# Altium schematic sheet Tutorial - Racer Schematic.SchDoc
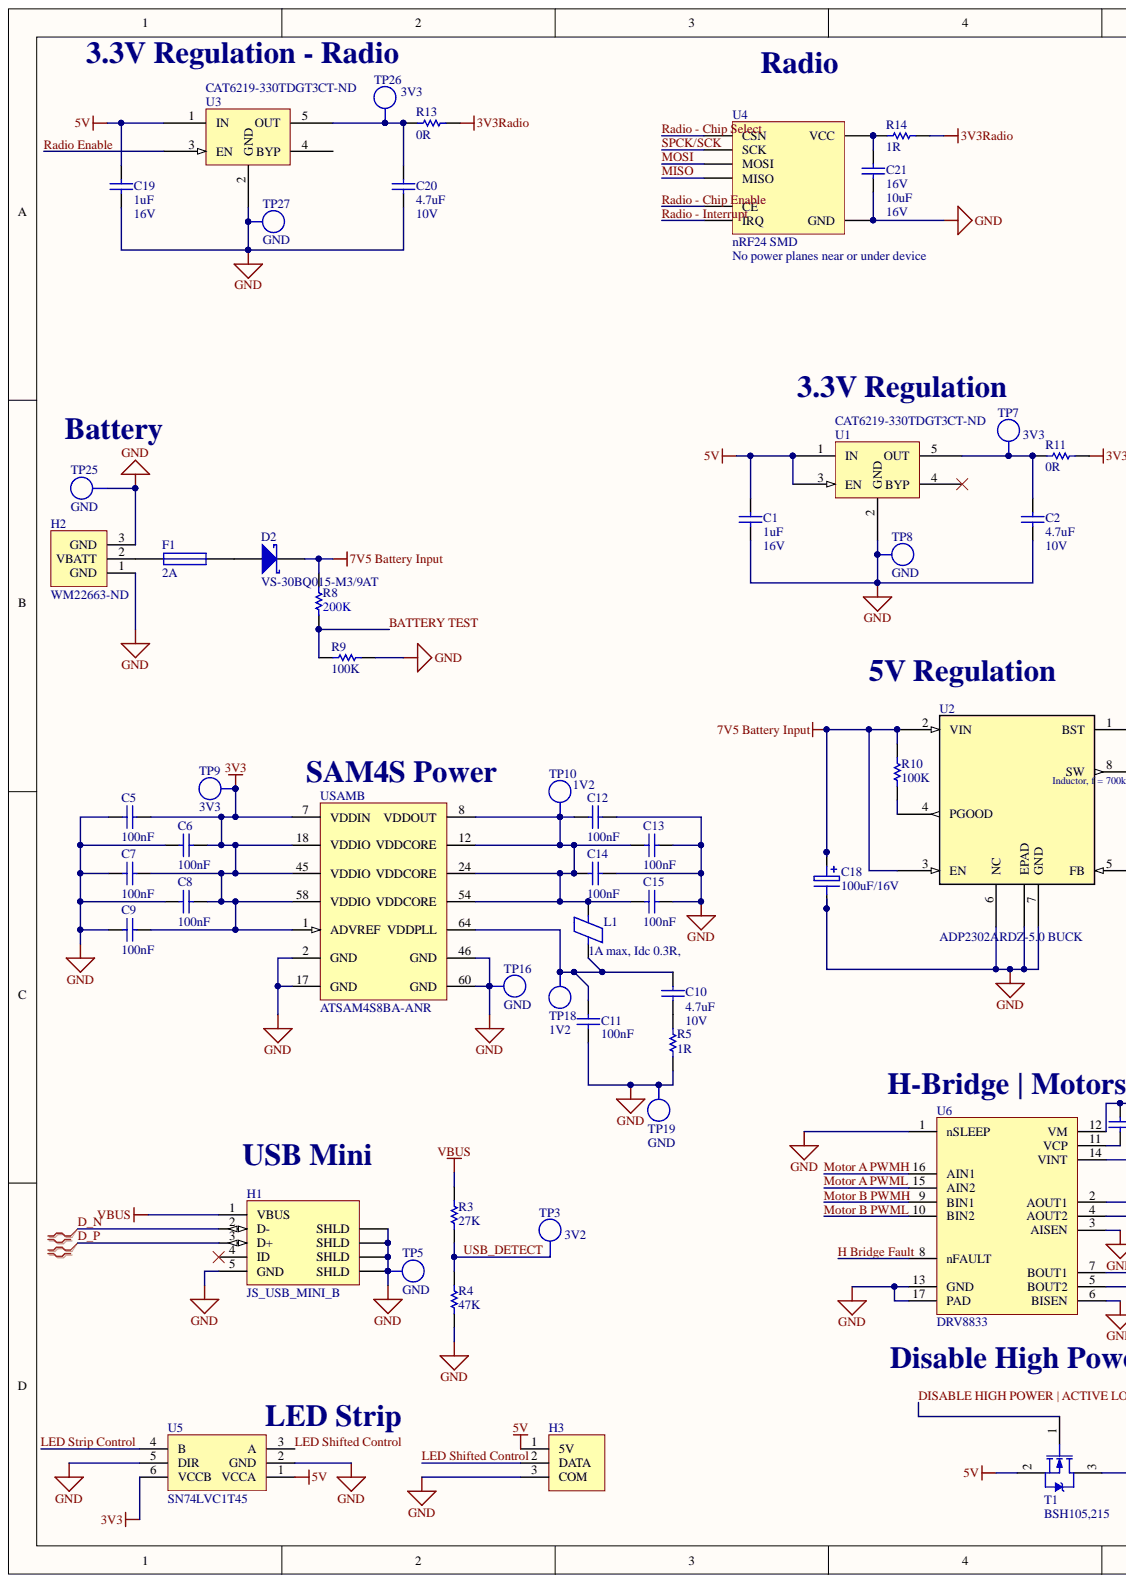
[diagram p0: Tutorial - Racer Schematic.SchDoc, left]
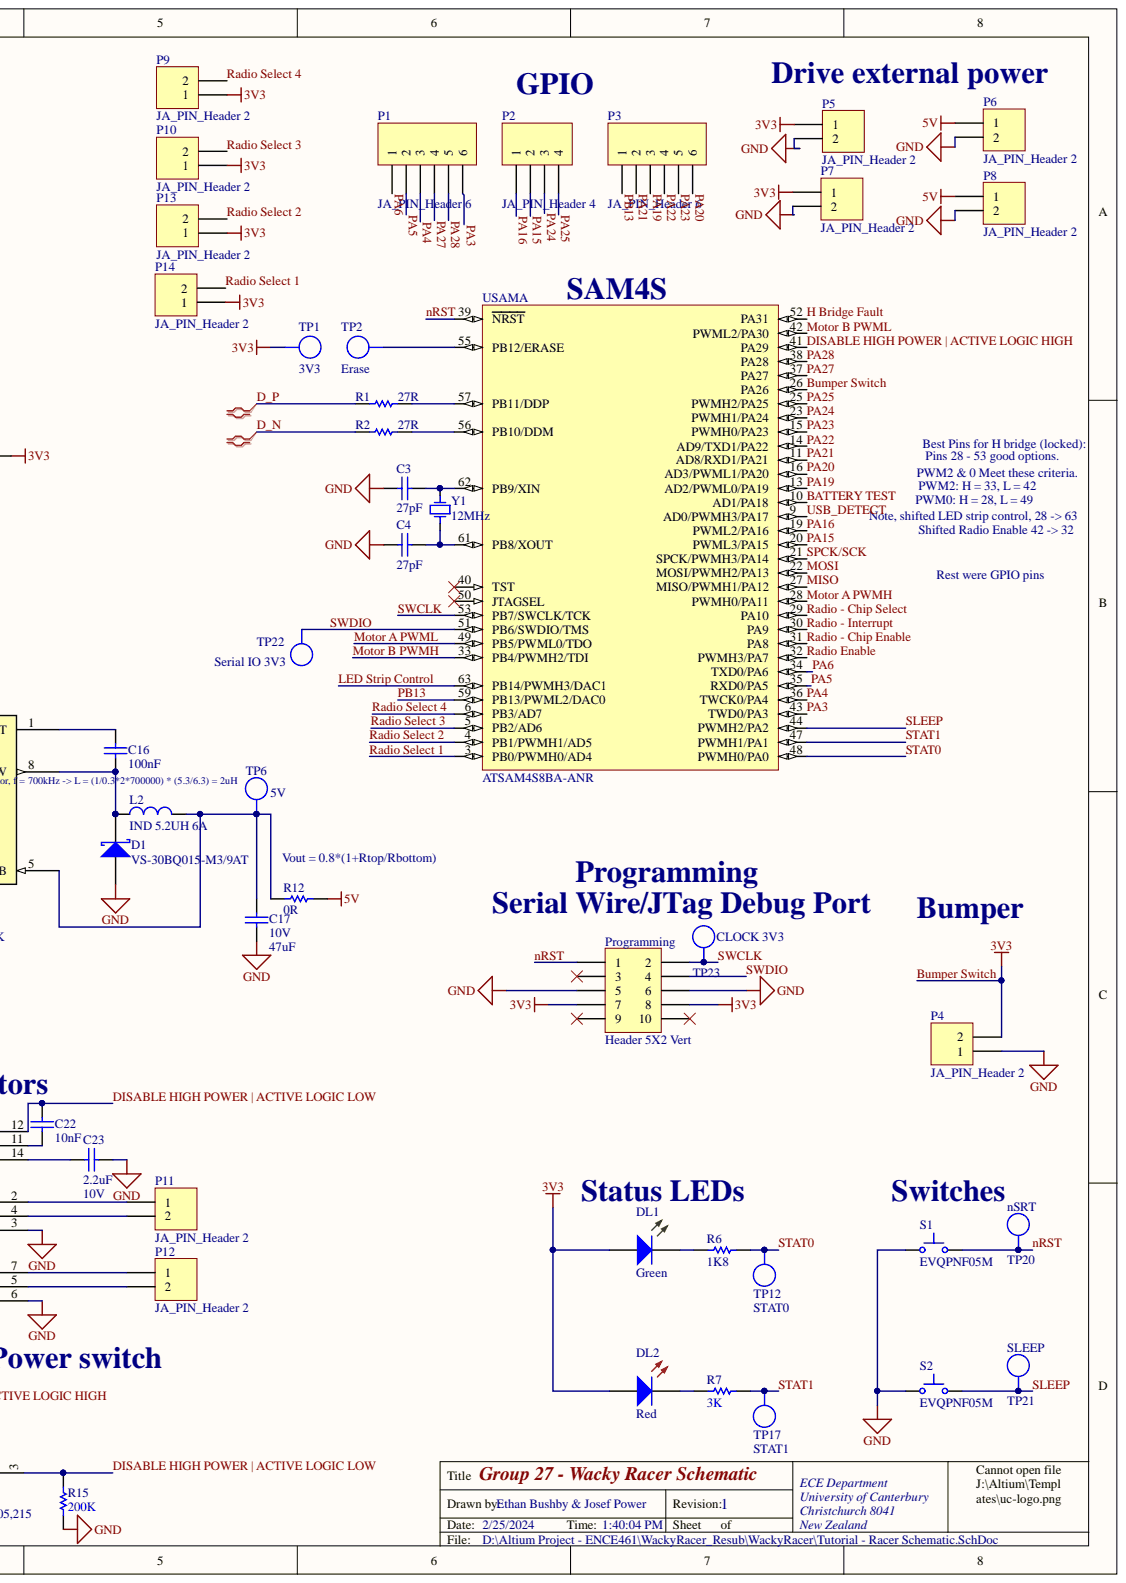
[diagram p1: Tutorial - Racer Schematic.SchDoc, right]

## Parts
C1  1uF  Max Voltage: 16V  [CAPC1608L]
C2  4.7uF  Max Voltage: 10V  [CAPC1608N]
C3  27pF  [CAPC1608L]
C4  27pF  [CAPC1608L]
C5  100nF  [CAPC1608L]
C6  100nF  [CAPC1608L]
C7  100nF  [CAPC1608L]
C8  100nF  [CAPC1608L]
C9  100nF  [CAPC1608L]
C10  4.7uF  Max Voltage: 10V  [CAPC1608N]
C11  100nF  [CAPC1608L]
C12  100nF  [CAPC1608L]
C13  100nF  [CAPC1608L]
C14  100nF  [CAPC1608L]
C15  100nF  [CAPC1608L]
C16  100nF  [CAPC1608L]
C17  47uF  Max Voltage: 10V  [1210 (3225 metric)]
C18  100uF/16V  [NICH-1]
C19  1uF  Max Voltage: 16V  [CAPC1608L]
C20  4.7uF  Max Voltage: 10V  [CAPC1608N]
C21  10uF  Max Voltage: 16V  [CAPC2012L]
C22  10nF  [CAPC1608L]
C23  2.2uF  Max Voltage: 10V  [CAPC1608L]
D1  VS-30BQ015-M3/9AT  [SMC]
D2  VS-30BQ015-M3/9AT  [SMC]
DL1  Green  [WL-SMCW0603]
DL2  Red  [WL-SMCW0603]
F1  2A  [RESC3216N]
H1  JS_USB_MINI_B  [USB/SM0.8-6H5]
H2  Supplier Part Number 1: WM22663-ND  [BATT_HEADER_3POS]  (hidden comment: Battery_HEADER_3pin)
H3  3 Pin Molex KK Hdr  [MOLEX_KK_3_HDR]  (value not drawn)
L1  1A max, Idc 0.3R,  [INDC3216L]
L2  IND 5.2UH 6A  [INDUCTOR5u2]
P1  JA_PIN_Header 6  [HDR1X6]
P2  JA_PIN_Header 4  [HDR1X4]
P3  JA_PIN_Header 6  [HDR1X6]
P4  JA_PIN_Header 2  [HDR1X2]
P5  JA_PIN_Header 2  [HDR1X2]
P6  JA_PIN_Header 2  [HDR1X2]
P7  JA_PIN_Header 2  [HDR1X2]
P8  JA_PIN_Header 2  [HDR1X2]
P9  JA_PIN_Header 2  [HDR1X2]
P10  JA_PIN_Header 2  [HDR1X2]
P11  JA_PIN_Header 2  [HDR1X2]
P12  JA_PIN_Header 2  [HDR1X2]
P13  JA_PIN_Header 2  [HDR1X2]
P14  JA_PIN_Header 2  [HDR1X2]
Programming  Header 5X2 Vert  [IDC2.54-V10D]
R1  27R  [RESC1608L]
R2  27R  [RESC1608L]
R3  27K  [RESC1608L]
R4  47K  [RESC1608N]
R5  1R  [RESC3216L]
R6  1K8  [RESC1608L]
R7  3K  [RESC1608L]
R8  200K  [RESC1608L]
R9  100K  [RESC1608L]
R10  100K  [RESC1608L]
R11  0R  [RESC3216L]
R12  0R  [RESC3216L]
R13  0R  [RESC3216L]
R14  1R  [RESC3216L]
R15  200K  [RESC1608L]
S1  EVQPNF05M  [EVQPNF05M]
S2  EVQPNF05M  [EVQPNF05M]
T1  BSH105,215  [SOT23_L]
TP1  3V3  [TP_1206]  (no BOM)
TP2  Erase  [TP_1206]  (no BOM)
TP3  3V2  [TP_1206]  (no BOM)
TP5  GND  [TP_1206]  (no BOM)
TP6  5V  [TP_1206]  (no BOM)
TP7  3V3  [TP_1206]  (no BOM)
TP8  GND  [TP_1206]  (no BOM)
TP9  3V3  [TP_1206]  (no BOM)
TP10  1V2  [TP_1206]  (no BOM)
TP12  STAT0  [TP_1206]  (no BOM)
TP16  GND  [TP_1206]  (no BOM)
TP17  STAT1  [TP_1206]  (no BOM)
TP18  1V2  [TP_1206]  (no BOM)
TP19  GND  [TP_1206]  (no BOM)
TP20  nSRT  [TP_1206]  (no BOM)
TP21  SLEEP  [TP_1206]  (no BOM)
TP22  Serial IO 3V3  [TP_1206]  (no BOM)
TP23  CLOCK 3V3  [TP_1206]  (no BOM)
TP25  GND  [TP_1206]  (no BOM)
TP26  3V3  [TP_1206]  (no BOM)
TP27  GND  [TP_1206]  (no BOM)
U1  CAT6219-330TDGT3  Supplier Part Number: CAT6219-330TDGT3CT-ND  [SOT95P280X145-5N]
U2  ADP2302ARDZ-5.0 BUCK  Frequency - Switching: 700kHz  Voltage - Output (Min/Fixed): 5V  [RD_8_1]
U3  CAT6219-330TDGT3  Supplier Part Number: CAT6219-330TDGT3CT-ND  [SOT95P280X145-5N]
U4  nRF24 SMD  Warning: No power planes near or under device  [NRF24]
U5  SN74LVC1T45  [SOT23-6_N]
U6  DRV8833  [HTSOP16 DRV8833]
USAM  ATSAM4S8BA-ANR  [LQFP64_L]  (parts A,B)
Y1  12MHz  [6.0X3.5-4]

## Nets
3V3Radio: R13.2 R14.2
5V: C1.2 C19.2 H3.1 P6.1 P8.1 R12.2 T1.2 U1.1(IN) U1.3(EN) U3.1(IN) U5.1(VCCA)
7V5 Battery Input: C18.2 D2.2 R8.2 R10.2 U2.2(VIN) U2.3(EN)
GND: C1.1 C2.1 C3.1 C4.1 C5.1 C6.1 C7.1 C8.1 C9.1 C11.1 C12.2 C13.2 C14.2 C15.2 C17.1 C18.1 C19.1 C20.1 C21.1 C23.2 D1.1 H1.5(GND) H1.6(SHLD) H1.7(SHLD) H1.8(SHLD) H1.9(SHLD) H2.1 H2.3 H3.3 P4.1 P5.2 P6.2 P7.2 P8.2 Programming.5 Programming.6 R4.1 R5.1 R9.2 R15.1 S1.1 S2.1 TP5.1 TP8.1 TP16.1 TP19.1 TP25.1 TP27.1 U1.2(GND) U2.6(NC) U2.7(GND) U2.EPAD U3.2(GND) U4.2(GND) U5.2(GND) U5.5(DIR) U6.1(nSLEEP) U6.3(AISEN) U6.6(BISEN) U6.13(GND) U6.17(PAD) USAM.2(GND) USAM.17(GND) USAM.46(GND) USAM.60(GND)
VBUS: H1.1(VBUS) R3.2
BATTERY TEST: R8.1 R9.1 USAM.10(AD1/PA18)
Bumper Switch: C5.2 C6.2 C7.2 C8.2 C9.2 DL1.2 DL2.2 P4.2 P5.1 P7.1 P9.1 P10.1 P13.1 P14.1 Programming.7 Programming.8 R11.2 TP1.1 TP9.1 U5.6(VCCB) USAM.1(ADVREF) USAM.7(VDDIN) USAM.18(VDDIO) USAM.26(PA26) USAM.45(VDDIO) USAM.58(VDDIO)
D_N: H1.2(D-) R2.1
D_P: H1.3(D+) R1.1
DISABLE HIGH POWER | ACTIVE LOGIC HIGH: T1.1 USAM.41(PA29)
DISABLE HIGH POWER | ACTIVE LOGIC LOW: C22.2 R15.2 T1.3 U6.12(VM)
H Bridge Fault: U6.8(nFAULT) USAM.52(PA31)
LED Shifted Control: H3.2 U5.3(A)
LED Strip Control: U5.4(B) USAM.63(PB14/PWMH3/DAC1)
MISO: U4.7(MISO) USAM.27(MISO/PWMH1/PA12)
MOSI: U4.6(MOSI) USAM.22(MOSI/PWMH2/PA13)
Motor A PWMH: U6.16(AIN1) USAM.28(PWMH0/PA11)
Motor A PWML: U6.15(AIN2) USAM.49(PB5/PWML0/TDO)
Motor B PWMH: U6.9(BIN1) USAM.33(PB4/PWMH2/TDI)
Motor B PWML: U6.10(BIN2) USAM.42(PWML2/PA30)
nRST: Programming.1 S1.2 TP20.1 USAM.39(NRST)
PA3: P1.6 USAM.43(TWD0/PA3)
PA4: P1.3 USAM.36(TWCK0/PA4)
PA5: P1.2 USAM.35(RXD0/PA5)
PA6: P1.1 USAM.34(TXD0/PA6)
PA15: P2.2 USAM.20(PWML3/PA15)
PA16: P2.1 USAM.19(PWML2/PA16)
PA19: P3.3 USAM.13(AD2/PWML0/PA19)
PA20: P3.6 USAM.16(AD3/PWML1/PA20)
PA21: P3.2 USAM.11(AD8/RXD1/PA21)
PA22: P3.4 USAM.14(AD9/TXD1/PA22)
PA23: P3.5 USAM.15(PWMH0/PA23)
PA24: P2.3 USAM.23(PWMH1/PA24)
PA25: P2.4 USAM.25(PWMH2/PA25)
PA27: P1.4 USAM.37(PA27)
PA28: P1.5 USAM.38(PA28)
PB13: P3.1 USAM.59(PB13/PWML2/DAC0)
Radio - Chip Enable: U4.3(Enable) USAM.31(PA8)
Radio - Chip Select: U4.4(Select) USAM.29(PA10)
Radio - Interrupt: U4.8(IRQ) USAM.30(PA9)
Radio Enable: U3.3(EN) USAM.32(PWMH3/PA7)
Radio Select 1: P14.2 USAM.3(PB0/PWMH0/AD4)
Radio Select 2: P13.2 USAM.4(PB1/PWMH1/AD5)
Radio Select 3: P10.2 USAM.5(PB2/AD6)
Radio Select 4: P9.2 USAM.6(PB3/AD7)
SLEEP: S2.2 TP21.1 USAM.44(PWMH2/PA2)
SPCK/SCK: U4.5(SCK) USAM.21(SPCK/PWMH3/PA14)
STAT0: R6.2 TP12.1 USAM.48(PWMH0/PA0)
STAT1: R7.2 TP17.1 USAM.47(PWMH1/PA1)
SWCLK: Programming.2 TP23.1 USAM.53(PB7/SWCLK/TCK)
SWDIO: Programming.4 TP22.1 USAM.51(PB6/SWDIO/TMS)
USB_DETECT: R3.1 R4.2 TP3.1 USAM.9(AD0/PWMH3/PA17)
NetC2_2: C2.2 R11.1 TP7.1 U1.5(OUT)
NetC3_2: C3.2 USAM.62(PB9/XIN) Y1.3
NetC4_2: C4.2 USAM.61(PB8/XOUT) Y1.1
NetC10_1: C10.1 R5.2
NetC10_2: C10.2 C11.2 L1.1 TP18.1 USAM.64(VDDPLL)
NetC12_1: C12.1 C13.1 C14.1 C15.1 L1.2 TP10.1 USAM.8(VDDOUT) USAM.12(VDDCORE) USAM.24(VDDCORE) USAM.54(VDDCORE)
NetC16_1: C16.1 D1.2 L2.1 U2.8(SW)
NetC16_2: C16.2 U2.1(BST)
NetC17_2: C17.2 L2.2 R12.1 TP6.1 U2.5(FB)
NetC20_2: C20.2 R13.1 TP26.1 U3.5(OUT)
NetC21_2: C21.2 R14.1 U4.1(VCC)
NetC22_1: C22.1 U6.11(VCP)
NetC23_1: C23.1 U6.14(VINT)
NetD2_1: D2.1 F1.2
NetDL1_1: DL1.1 R6.1
NetDL2_1: DL2.1 R7.1
NetF1_1: F1.1 H2.2
NetP11_1: P11.1 U6.2(AOUT1)
NetP11_2: P11.2 U6.4(AOUT2)
NetP12_1: P12.1 U6.7(BOUT1)
NetP12_2: P12.2 U6.5(BOUT2)
NetR1_2: R1.2 USAM.57(PB11/DDP)
NetR2_2: R2.2 USAM.56(PB10/DDM)
NetR10_1: R10.1 U2.4(PGOOD)
NetTP2_1: TP2.1 USAM.55(PB12/ERASE)

Not connected: H1 4(ID); Programming 3 9 10; U1 4(BYP); U3 4(BYP); USAM 40(TST) 50(JTAGSEL)

## Library data of the parts
C1 C19 = CC_1uF_0603: CAP CER 1uF Y5V -20% +80% 16V 0603; Code_IPC: 1608 [0603]; Component Kind: Standard; Library Name: ECE AD19Components_22V1.IntLib; PackageReference: 0603; Simulation: CAP; Storage Location: PCB Assembly WS; Supplier: ECE Dept; Value: 1uF
C2 C10 C20 = CC_4.7uF_0603: CAP CER 4.7uF X5R +-20%  10V 0603; Note: Footprint corresponds to dimensions for bulk cassette packaging. This case size has tightened tolerances.; Code_IPC: 2012[0805]; Component Kind: Standard; Library Name: ECE AD19Components_22V1.IntLib; PackageReference: 0805; Simulation: CAP; Storage Location: PCB Assembly WS; Supplier: ECE Dept; Value: 4.7uF
C3 C4 = CC_27pF_0603: CAP CER 27pF NPO/COG +-5% 50V 0603; Code_IPC: 1608 [0603]; Component Kind: Standard; Library Name: ECE AD19Components_22V1.IntLib; PackageReference: 0603; Simulation: CAP; Storage Location: PCB Assembly WS; Supplier: ECE Dept; Value: 27pF
C5-C9 C11-C16 = CC_100nF_0603: CAP CER 100nF X7R +-10% 50V 0603; Code_IPC: 1608 [0603]; Component Kind: Standard; Library Name: ECE AD19Components_22V1.IntLib; PackageReference: 0603; Simulation: CAP; Storage Location: PCB Assembly WS; Supplier: ECE Dept; Value: 100nF
C17 = CC_47uF_1206: CAP CER 47uF X5R -/+20% 10V 1206; Component Kind: Standard; Dielectric: X5R; Library Name: ECE AD19Components_22V1.IntLib; MoV: 10V; Simulation: CAP; Storage Location: PCB Assembly WS; Supplier: ECE Dept; Value: 47uF
C18 = CA_100uF_16V: CAP ALUM 100uF 16V SMD; Manufacturer: Nichcon; Manufacturer PN: UWX1C101MCL1GB; Component Kind: Standard; Library Name: ECE AD19Components_22V1.IntLib; Storage Location: PCB Assembly WS; Supplier: ECE Dept; Supplier 1: Farnell; Supplier 2: Digi-Key; Supplier Part Number 1: 1870677; Supplier Part Number 2: 493-2105-1-ND; Value: 100uF/16V
C21 = CC_10uF_0805_16V: CAP CER 10uF X5R 16V 0805; Manufacturer: Samsung Electro-Mechanics; Manufacturer Part Number: CL21A106KOQNNWE; Note: Footprint corresponds to dimensions for bulk cassette packaging. This case size has tightened tolerances.; Notification: Current market demand for these product types have resulted in fluctuating and extending lead times. Lead times may differ.; Tolerance: ±10%; Applications: General Purpose; Category: Capacitors; Code_IPC: 2012[0805]; Component Kind: Standard; ComponentLink1Description: http://www.samsungsem.com/kr/support/product-search/mlcc/CL21A106KOQNNWE.jsp; ComponentLink1URL: http://www.samsungsem.com/kr/support/product-search/mlcc/CL21A106KOQNNWE.jsp; Features: -; Height - Seated (Max): -; Lead Spacing: -; Lead Style: -; Library Name: ECE AD19Components_22V1.IntLib; Mounting Type: Surface Mount, MLCC; Operating Temperature: -55°C ~ 85°C; Package / Case: 0805 (2012 Metric); PackageReference: 0805; Packaging: Cut Tape (CT); Part Status: Active; Pricing 1: 1=0.35, 10=0.25, 100=0.1178, 500=0.08254, 1000=0.06831 (NZD); Ratings: -; RoHS: RoHS Compliant; Simulation: CAP; Size / Dimension: 0.079" L x 0.049" W (2.00mm x 1.25mm); Stock 1: 20000; Supplier 1: Digi-Key; Supplier Part Number 1: 1276-2894-1-ND; Temperature Coefficient: X5R; Thickness (Max): 0.055" (1.40mm); Value: 10uF; Voltage - Rated: 16V
C22 = CC_10nF_0603: CAP CER 10nF X7R +-10% 50V 0603; Code_IPC: 1608 [0603]; Component Kind: Standard; Library Name: ECE AD19Components_22V1.IntLib; PackageReference: 0603; Simulation: CAP; Storage Location: PCB Assembly WS; Supplier: ECE Dept; Value: 10nF
C23 = CC_2.2uF_0603: CAP CER 2.2uF X5R +-20% 10V 0603; Code_IPC: 1608 [0603]; Component Kind: Standard; Library Name: ECE AD19Components_22V1.IntLib; PackageReference: 0603; Simulation: CAP; Storage Location: PCB Assembly WS; Supplier: ECE Dept; Value: 2.2uF
D1 D2 = DS_VS-30BQ015-M3: DIODE, SCHOTTKY, 3A, 15V, DO-214AB / SMC; Manufacturer: Vishay Semiconductors; Forward Voltage: 350mV; Max Forward Surge Current (Ifsm): 650A; Peak Reverse Current: 4mA; Average Rectified Current: 3A; Component Kind: Standard; ComponentLink1Description: https://datasheet.ciiva.com/36181/vs-30bq015-m3-9at-36181258.pdf; ComponentLink1URL: https://datasheet.ciiva.com/36181/vs-30bq015-m3-9at-36181258.pdf; Library Name: ECE AD19Components_22V1.IntLib; Manufacturer Part Number: VS-30BQ015-M3/9AT; Max Reverse Voltage (DC): 15V; Supplier: Digi-Key; Supplier 1: Digi-Key; Supplier 2: Element14; Supplier Part Number: VS-30BQ015-M3/9AT-ND; Supplier Part Number 1: VS-30BQ015-M3/9ATGIDKR-ND; Supplier Part Number 2: 2628288
DL1 = DL_150060GS75000: LED Green 430mcd 0603 SMD; Manufacturer: WURTH ELEKTRONIK; Forward Current If: 30; Forward Voltage: 3.2; Lens Shape: Rectangular; Luminous Intensity: 430; Viewing Angle: 140°; Wavelength Typ: 520; Component Kind: Standard; LED Colour: Green; LED Mounting: SMD; Library Name: ECE AD19Components_22V1.IntLib; Manufacturer Part Number: 150060GS75000; Storage Location: PCB Assembly WS; Supplier: ECE Dept; Supplier 1: Farnell; Supplier Part Number 1: 2322070
DL2 = DL_150060RS75000: LED Red 250mcd 0603 SMD; Manufacturer: WURTH ELEKTRONIK; Forward Current If: 30; Forward Voltage: 2; Lens Shape: Rectangular; Luminous Intensity: 250; Viewing Angle: 140°; Wavelength Typ: 625; Component Kind: Standard; LED Colour: Red; LED Mounting: SMD; Library Name: ECE AD19Components_22V1.IntLib; Manufacturer Part Number: 150060RS75000; Storage Location: PCB Assembly WS; Supplier: ECE Dept; Supplier 1: Farnell; Supplier Part Number 1: 2322071
F1 = FS_Fuse_2.0A: Fuse 2.0A Very Fast Acting 1206 SMD; Manufacturer: MULTICOMP; Manufacturer Part Number: MC12CF 002; Component Kind: Standard; Library Name: ECE AD19Components_22V1.IntLib; Manufacturer PN: MC12CF 002; Storage Location: PCB Assembly WS; Supplier: ECE Dept; Supplier 1: Farnell; Supplier Part Number 1: 1841029; Value: 2A
H1 = JS_USB_MINI_B_SMT: USB 2.0, Right Angle, SMT, B Type, Receptacle, 5 Position,; Manufacturer: FCI; Manufacturer PN: 10033526-N3212MLF; Component Kind: Standard; Library Name: ECE AD19Components_22V1.IntLib; Storage Location: PCB Assembly WS; Supplier: ECE Dept; Supplier 1: Farnell; Supplier Part Number 1: 1824416
H2 = Battery_HEADER_3pin: 3Pin Header 2.54mm spacing  Digikey #WM22663-ND; Component Kind: Standard; Library Name: ENCE461AD19_V23.IntLib; Supplier 1: Digi-Key
H3 = LED_Strip_Header: CONN HEADER 3POS 2.54mm VERT_Thru Hole; Component Kind: Standard; Library Name: ENCE461AD19_V23.IntLib; Manufacturer: Molex; Manufacturer PN: 22-23-2031; Storage Location: ECE Dept Store; Supplier: ECE Dept; Supplier 1: Farnell; Supplier Part Number 1: 9731156; Value: 3 Pin Molex KK Hdr
L1 = IN_Ferrite-Bead: Ferrite Bead, Rdc 0.3R, Z100MHZ 600R, Imax 1A, SMD 1206; Manufacturer: FAIR-RITE; Manufacturer Part Number: 2512066017Y1; DC Current Rating: 1; DC Resistance Max: 0.08; Ferrite Case Style: 1206 ^3216 Metric]; Frequency Range: 50MHz to 1; Impedance: 600; Impedance Tolerance: ± 25%; Component Kind: Standard; Ferrite Mounting: SMD; Library Name: ECE AD19Components_22V1.IntLib; Manufacturer PN: 2512066017Y1; Storage Location: PCB Assembly WS; Supplier: ECE Dept; Supplier 1: Farnell; Supplier Part Number 1: 1612060; Value: 1A max, Idc 0.3R,
L2 = CDRH104RNP-5R2NC: FIXED IND 5.2UH 6A 22 MOHM SMD; Manufacturer: Sumida America Components Inc.; Current - Saturation: 5.6A; DC Resistance (DCR): 22 mOhm Max; Material - Core: Ferrite; Shielding: Shielded; Tolerance: ±30%; Category: Inductors, Coils, Chokes; Component Kind: Standard; ComponentLink1Description: http://products.sumida.com/products/pdf/CDRH104R.pdf; ComponentLink1URL: http://products.sumida.com/products/pdf/CDRH104R.pdf; Frequency - Self Resonant: -; Height - Seated (Max): 0.157" (4.00mm); Library Name: ENCE461AD19_V23.IntLib; Manufacturer Part Number: CDRH104RNP-5R2NC; Mounting Type: Surface Mount; Operating Temperature: -40°C ~ 100°C; Package / Case: Nonstandard; Packaging: Cut Tape (CT); Part Status: Active; Pricing 1: 1=2.9, 10=2.678, 100=1.8743, 500=1.5173 (NZD); Q @ Freq: -; Ratings: -; RoHS: RoHS Compliant; Size / Dimension: 0.402" L x 0.394" W (10.20mm x 10.00mm); Stock 1: 3849; Supplier 1: Digi-Key; Supplier Device Package: -; Supplier Part Number 1: 308-2280-1-ND; Type: -
P1 P3 = JA_PIN_Header 6: Header, 6-Pin; Component Kind: Standard; LatestRevisionDate: 17-Jul-2002; LatestRevisionNote: Re-released for DXP Platform.; Library Name: ECE AD19Components_22V1.IntLib; Publisher: Altium Limited
P2 = JA_PIN_Header 4: Header, 4-Pin; Component Kind: Standard; LatestRevisionDate: 17-Jul-2002; LatestRevisionNote: Re-released for DXP Platform.; Library Name: ECE AD19Components_22V1.IntLib; Publisher: Altium Limited
P4-P14 = JA_PIN_Header 2: Header, 2-Pin; Component Kind: Standard; LatestRevisionDate: 17-Jul-2002; LatestRevisionNote: Re-released for DXP Platform.; Library Name: ECE AD19Components_22V1.IntLib; Publisher: Altium Limited
Programming = JA_HDR_IDC_5X2_VERT: IDC Header, 2,54mm, 10-Pin, Vert; Component Kind: Standard; Library Name: ECE AD19Components_22V1.IntLib; Storage Location: ECE Dept Store; Supplier: ECE Dept; Supplier 1: Farnell; Supplier Part Number 1: 1096985
R1 R2 = RS_27R: RES 27 OHM 1/10W 5% 0603 SMD; Component Kind: Standard; Library Name: ECE AD19Components_22V1.IntLib; Simulation: RESISTOR; Storage Location: PCB Assembly WS; Supplier: ECE Dept; Value: 27R
R3 = RS_27K: RES 27K OHM 1/10W 5% 0603 SMD; Component Kind: Standard; Library Name: ECE AD19Components_22V1.IntLib; Simulation: RESISTOR; Storage Location: PCB Assembly WS; Supplier: ECE Dept; Value: 27K
R4 = RS_47K: RES 47.0K OHM 1/10W 5% 0603 SMD; Component Kind: Standard; Library Name: ECE AD19Components_22V1.IntLib; Simulation: RESISTOR; Storage Location: PCB Assembly WS; Supplier: ECE Dept; Value: 47K
R5 R14 = RT_1R: RES 1R OHM 1/4W 5% 1206 SMD; Component Kind: Standard; Library Name: ECE AD19Components_22V1.IntLib; Storage Location: PCB Assembly WS; Supplier: ECE Dept; Value: 1R
R6 = RS_1K8: RES 1.8K OHM 1/10W 5% 0603 SMD; Component Kind: Standard; Library Name: ECE AD19Components_22V1.IntLib; Simulation: RES; Storage Location: PCB Assembly WS; Supplier: ECE Dept; Value: 1K8
R7 = RS_3K: RES 3K OHM 1/10W 5% 0603 SMD; Component Kind: Standard; Library Name: ECE AD19Components_22V1.IntLib; Simulation: RESISTOR; Storage Location: PCB Assembly WS; Supplier: ECE Dept; Value: 3K
R8 R15 = RS_200K: RES 200K OHM 1/10W 5% 0603 SMD; Component Kind: Standard; Library Name: ECE AD19Components_22V1.IntLib; Simulation: RES; Storage Location: PCB Assembly WS; Supplier: ECE Dept; Value: 200K
R9 R10 = RS_100K: RES 100K OHM 1/10W 5% 0603 SMD; Component Kind: Standard; Library Name: ECE AD19Components_22V1.IntLib; Simulation: RESISTOR; Storage Location: PCB Assembly WS; Supplier: ECE Dept; Value: 100K
R11-R13 = RT_0R: RES 0R OHM 1/8W 5% 1206 SMD; Component Kind: Standard; Library Name: ECE AD19Components_22V1.IntLib; Storage Location: PCB Assembly WS; Supplier: ECE Dept; Value: 0R
S1 S2 = WS_EVQPNF05M: Panasonic 6 x 3.5mm SMD Light Touch Switch Type SMD; Component Kind: Standard; Library Name: ECE AD19Components_22V1.IntLib; Manufacturer: Panasonic; Manufacturer PN: EVQPNF05M; PackageDescription: 6.0 x 3.5 mm; Storage Location: PCB Assembly WS; Supplier: ECE Dept; Supplier 1: Farnell; Supplier 2: Digi-Key; Supplier Part Number 1: 2079481; Supplier Part Number 2: P13598SCT-ND
T1 = TM_BSH105: MOSFET N-CH 20V 1.05A SOT-23; Manufacturer: NXP Semiconductors; FET Type: MOSFET N-Channel, Metal Oxide; Gate Charge (Qg) @ Vgs: 3.9nC @ 4.5V; Input Capacitance (Ciss) @ Vds: 152pF @ 16V; Power - Max: 417mW; Rds On (Max) @ Id, Vgs: 200 mOhm @ 600mA, 4.5V; Component Kind: Standard; Current - Continuous Drain (Id) @ 25° C: 1.05A; Drain to Source Voltage (Vdss): 20V; Library Name: ECE AD19Components_22V1.IntLib; Manufacturer Part Number: BSH105,215; Package / Case: TO-236-3, SC-59, SOT-23-3; Pricing: 1=0.53, 10=0.42, 25=0.3544, 100=0.2885, 250=0.23896, 500=0.1974, 1000=0.14785 (NZD); Storage Location: PCB Assembly WS; Supplier: ECE Dept; Supplier 1: Farnell; Supplier 2: Digi-Key; Supplier Part Number 1: 1758066; Supplier Part Number 2: 568-6215-1-ND
TP1-TP3 TP5-TP10 TP12 TP16-TP23 TP25-TP27 = TP_1206: Test-Point 3.3x1.6x2.1mm SMD; Manufacturer: Harwin Inc; Manufacturer Part Number: S1751-46R; Value: TP; Library Name: ECE AD19Components_22V1.IntLib; Mounting Type: Surface Mount; Storage Location: ProtoPlace; Supplier: ECE Dept; Supplier 1: Digi-Key; Supplier 2: Farnell; Supplier Part Number 1: 952-1478-2-ND; Supplier Part Number 2: 1826282; Type: PC Test Point
U1 U3 = SV_CAT6219-330TDGT3: IC REG LIN 3.3V 500MA TSOT23-5; Voltage - Input (Max): 5.5V; Voltage Dropout (Max): 0.5V @ 500mA; Base Part Number: CAT6219; Component Kind: Standard; ComponentLink1Description: http://www.onsemi.com/pub/Collateral/CAT6219-D.PDF; ComponentLink1URL: http://www.onsemi.com/pub/Collateral/CAT6219-D.PDF; ComponentLink2Description: CAT6219 Datasheet; ComponentLink2URL: \\maxwell\elecapp\AltiumDesigner\Libraries\DatasheetsNew\ENCE461\CAT6219-D.PDF; Current - Output: 500mA; Library Name: ENCE461AD19_V23.IntLib; Manufacturer Part Number: CAT6219-330TDGT3; Package / Case: SOT-23-5 Thin, TSOT-23-5; Supplier: Digi-Key; Supplier 1: Digi-Key; Supplier Device Package: TSOT-23-5; Voltage - Output (Min/Fixed): 3.3V
U2 = ADP2302ARDZ-5.0-R7: IC REG BUCK 5V 2A 8SOIC; Output Type: Fixed; Vendor: Analog Devices Inc; Voltage - Input (Max): 20V; Component Kind: Standard; ComponentLink1Description: ADP2302ARDZ-5.0 Datasheet; ComponentLink1URL: \\maxwell\elecapp\AltiumDesigner\Libraries\DatasheetsNew\ENCE461\ADP2302_2303.pdf; Current - Output: 2A; Library Name: ENCE461AD19_V23.IntLib; Manufacturer_Part_Number: ADP2302ARDZ-5.0-R7; Supplier 1: Digi-Key; Supplier Part Number 1: ADP2302ARDZ-5.0-R7CT-ND; Type: DEV; Value: Value
U4 = NRF24: nRF24 SMD Module; Component Kind: Standard; Library Name: ENCE461AD19_V23.IntLib
U5 = SN74LVC1T45: 1.8V - 5V level converter; Component Kind: Standard; Library Name: ENCE461AD19_V23.IntLib
U6 = DRV8833: Dual H-Bridge Motor Driver 1.5A; Component Kind: Standard; ComponentLink1Description: DRV8833 Datasheet; ComponentLink1URL: \\maxwell\elecapp\AltiumDesigner\Libraries\DatasheetsNew\ENCE461\drv8833pwpr.pdf; Library Name: ENCE461AD19_V23.IntLib
USAM = SE_ATSAM4S16BA-MU: IC MCU 32BIT 512KB FLASH 64LQFP; Manufacturer: Atmel; Connectivity: I²C, IrDA, Memory Card, SPI, SSC, UART/USART, USB; Core Processor: ARM® Cortex®-M4; Core Size: 32-Bit; Data Converters: A/D 11x12b, D/A 2x12b; Number of I/O: 47; Peripherals: Brown-out Detect/Reset, DMA, POR, PWM, WDT; Program Memory Size: 512KB (512K x 8); RAM Size: 128K x 8; Speed: 120MHz; Voltage - Supply (Vcc/Vdd): 1.62 V ~ 3.6 V; Code_JEDEC: MO-220VMMD-3; Component Kind: Standard; ComponentLink1Description: Manufacturer URL; ComponentLink1URL: http://www.atmel.com/; ComponentLink2Description: SAM4S Series Datasheet; ComponentLink2URL: http://www.atmel.com/dyn/resources/prod_documents/11100.pdf; ComponentLink3Description: SAM4S Series Summary; ComponentLink3URL: http://www.atmel.com/dyn/resources/prod_documents/11100S.pdf; Library Name: ECE AD19Components_22V1.IntLib; Manufacturer Part Number: ATSAM4S8BA-ANR; Package / Case: 64-LQFP; PackageDescription: 64-Pin Quad Flat No-Lead (QFN) Sawn, 9 x 9 x 1.0 mm Body, 0.5 mm Pitch, Exposed Pad; PackageReference: 64PS; PackageVersion: revJ, Jul-2007; Packaging: Cut Tape (CT); Program Memory Type: FLASH; Supplier 1: Digi-Key; Supplier Part Number 1: ATSAM4S8BA-ANRCT-ND
Y1 = XT_CRYSTAL_12MHz_18pF: CRYSTAL 12MHZ 18PF 6X3.5mm SMD; Manufacturer: CTS-Frequency Controls; Manufacturer Part Number: 406C35D12M00000; ESR (Equivalent Series Resistance): 60 Ohm; Frequency Stability: ±50ppm; Frequency Tolerance: ±30ppm; Operating Mode: Fundamental; Component Kind: Standard; Frequency: 12MHz; Height: 0.047" (1.20mm); Library Name: ECE AD19Components_22V1.IntLib; Load Capacitance: 18pF; Mounting Type: Surface Mount; Operating Temperature: -20°C ~ 70°C; Package / Case: 4-SMD, No Lead (DFN, LCC); Size / Dimension: 0.236" L x 0.138" W (6.00mm x 3.50mm); Supplier 1: Digi-Key; Supplier Part Number 1: CTX855CT-ND
